# Altium schematic sheet 12-M2_WiFi.SchDoc(12-M2_WiFi)
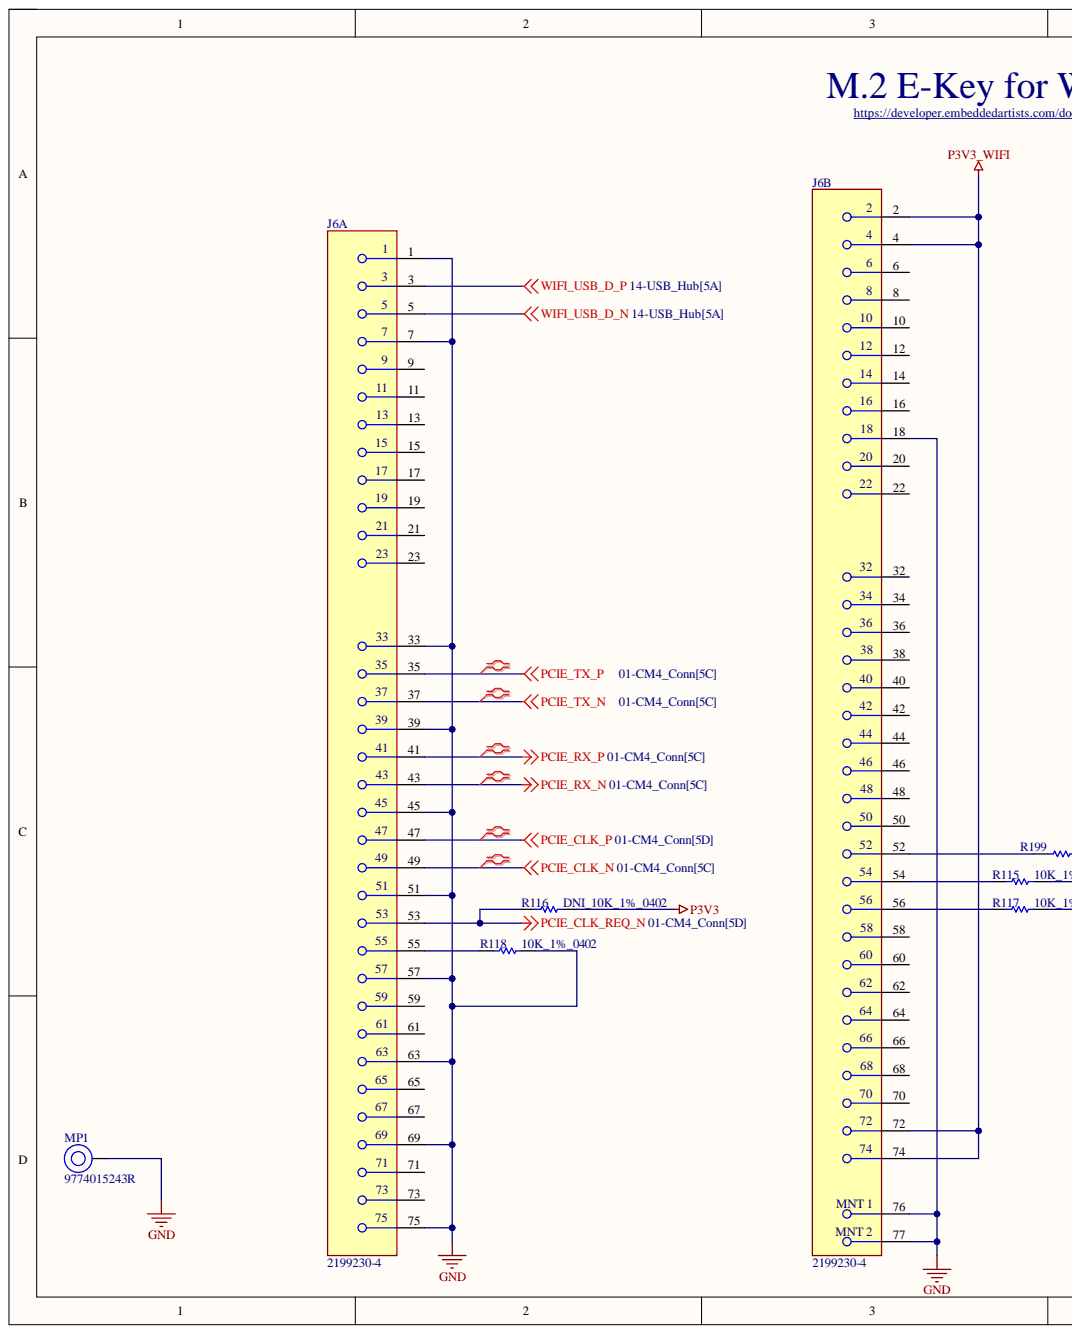
[diagram p0: 12-M2_WiFi.SchDoc, left]
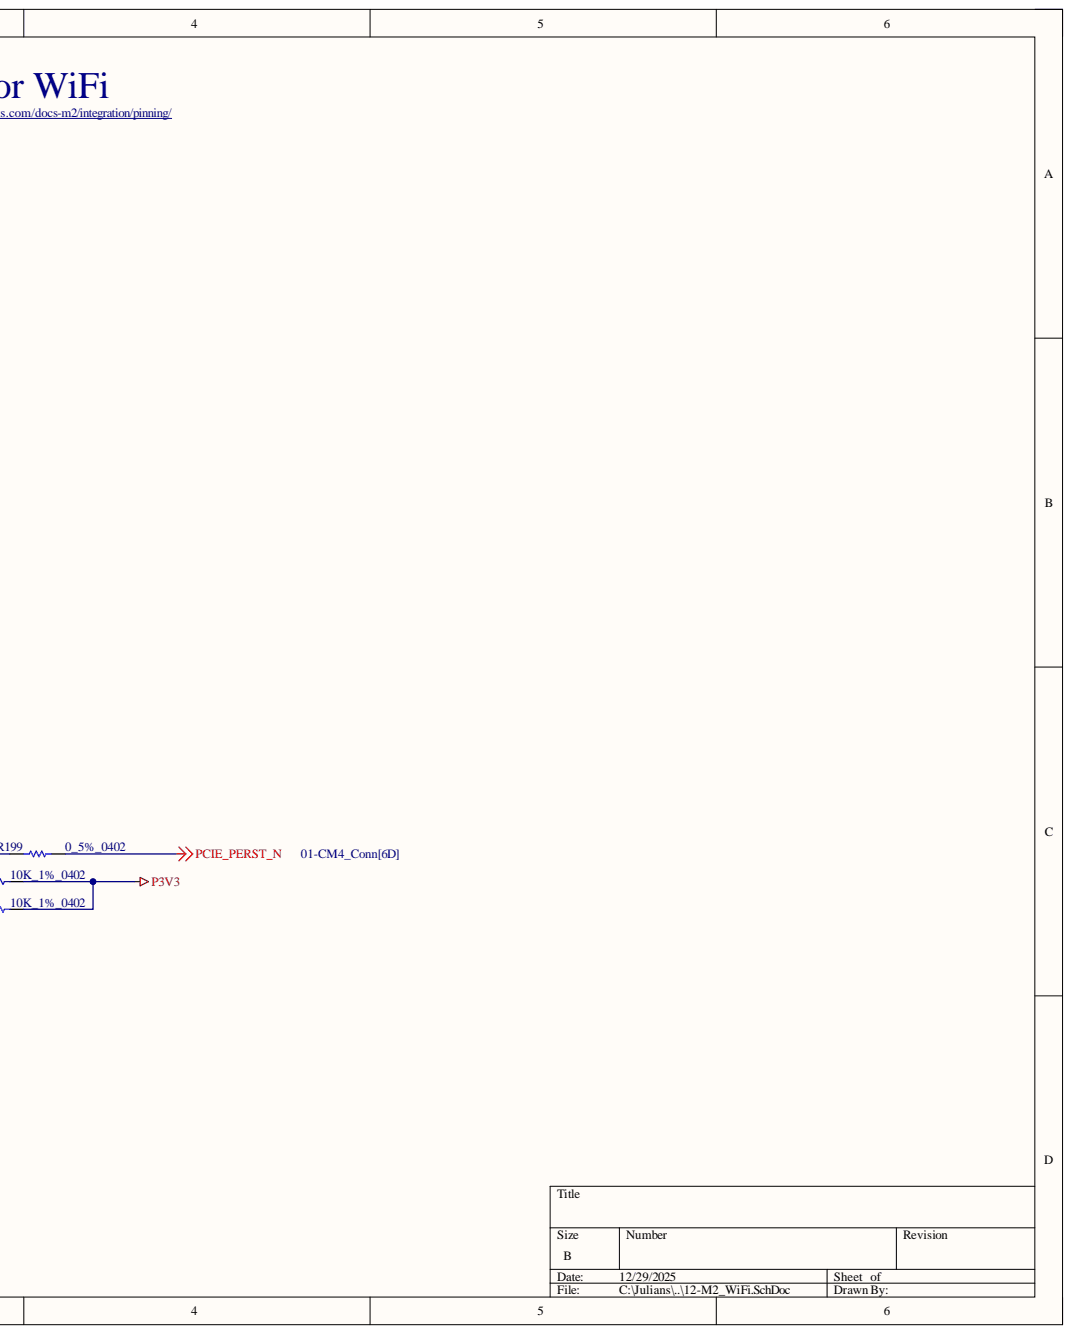
[diagram p1: 12-M2_WiFi.SchDoc, right]

## Parts
J6  2199230-4  [FP-2199230-4-MFG]  (parts A,B)
MP1  9774015243R  [9774015243]
R115  10K_1%_0402  [RESC1005X40X25NL10T10]  (no BOM)
R116  DNI_10K_1%_0402  [RESC1005X40X25NL10T10]  (no BOM)
R117  10K_1%_0402  [RESC1005X40X25NL10T10]  (no BOM)
R118  10K_1%_0402  [RESC1005X40X25NL10T10]  (no BOM)
R199  0_5%_0402  [RESC1005X40X25NL05T10]

## Nets
GND: J6.1 J6.7 J6.18 J6.33 J6.39 J6.45 J6.51 J6.57 J6.63 J6.69 J6.75 J6.76 J6.77 MP1.1 R118.2
P3V3: R115.2 R116.2 R117.2
P3V3_WIFI: J6.2 J6.4 J6.72 J6.74
PCIE_CLK_N: J6.49
PCIE_CLK_P: J6.47
PCIE_CLK_REQ_N: J6.53 R116.1
PCIE_PERST_N: R199.1
PCIE_RX_N: J6.43
PCIE_RX_P: J6.41
PCIE_TX_N: J6.37
PCIE_TX_P: J6.35
WIFI_USB_D_N: J6.5
WIFI_USB_D_P: J6.3
NetJ6_52: J6.52 R199.2
NetJ6_54: J6.54 R115.1
NetJ6_55: J6.55 R118.1
NetJ6_56: J6.56 R117.1

Not connected: J6 6 8 9 10 11 12 13 14 15 16 17 19 20 21 22 23 32 34 36 38 40 42 44 46 48 50 58 59 60 61 62 64 65 66 67 68 70 71 73

## Library data of the parts
J6 = CMP-03365-000002-1: CONN FEMALE 67POS 0.020 GOLD; Manufacturer: TE Connectivity; Conn Orientation: Right Angle; Current Rating: 0.5A; Is Female: yes; Number Of Contacts: 67; Pitch: 0.5mm; Voltage Rating: 50V; Automotive: No; Category: Conn; Component Kind: Standard; ComponentLink2Description: Datasheet; ComponentLink2URL: https://www.te.com/commerce/DocumentDelivery/DDEController?Action=showdoc&DocId=Customer+Drawing%7F2199230%7FB3%7Fpdf%7FEnglish%7FENG_CD_2199230_B3.pdf%7F2199230-4; Conn Gender: Female; Contact Material: Gold,Nickel; Device Class L1: Connectors; Device Class L2: Card Edge Connectors; Device Class L3: unset; Digikey Description: CONN FEMALE 67POS 0.020 GOLD; Height: 4.2mm; Lead Free: Yes; Library Name: Altium Content Vault; Manufacturer Part Number: 2199230-4; Mouser Description: PCI Express / PCI Connectors .5PITCH 4.2H KEY E EMBOSS NGFF MINICARD; Number Of Rows: 2; Octopart: https://octopart.com/2199230-4-te+connectivity-46436156; Package: 0; RoHS: TRUE; Temperature: -40°C to +80°C; Temperature Range High: +80°C; Temperature Range Low: -40°C
MP1 = CMP-03913-59774847-1: 9774015243R Spacer Material: Steel, Tin-Plated Mounting: SMT Series: WA-SMST Thread D: M2; Outside Diameter: 4.3434mm; Plating: Tin; Threaded/Unthreaded: Threaded; Component Kind: Standard; Height: 1.6mm; Length: 1.5mm; Library Name: Altium Content Vault; Material: Steel; Max Operating Temperature: 150°C; Min Operating Temperature: -55°C; NexarPartId: 59774847; Packaging: Tape and Reel; REACH SVHC: Unknown; RoHS: Compliant
R115-R118 = CMP-2002-04694-1: Manufacturer: Bourns; Part Number: CR0402-FX-1002GLF; Library Name: Altium Content Vault
R199 = CMP-2002-06457-1: Manufacturer: Panasonic; Part Number: ERJ-U020R00X; Component Kind: Standard; Library Name: Altium Content Vault
